# Altium schematic sheet 1_MISC.SchDoc(1_MISC)
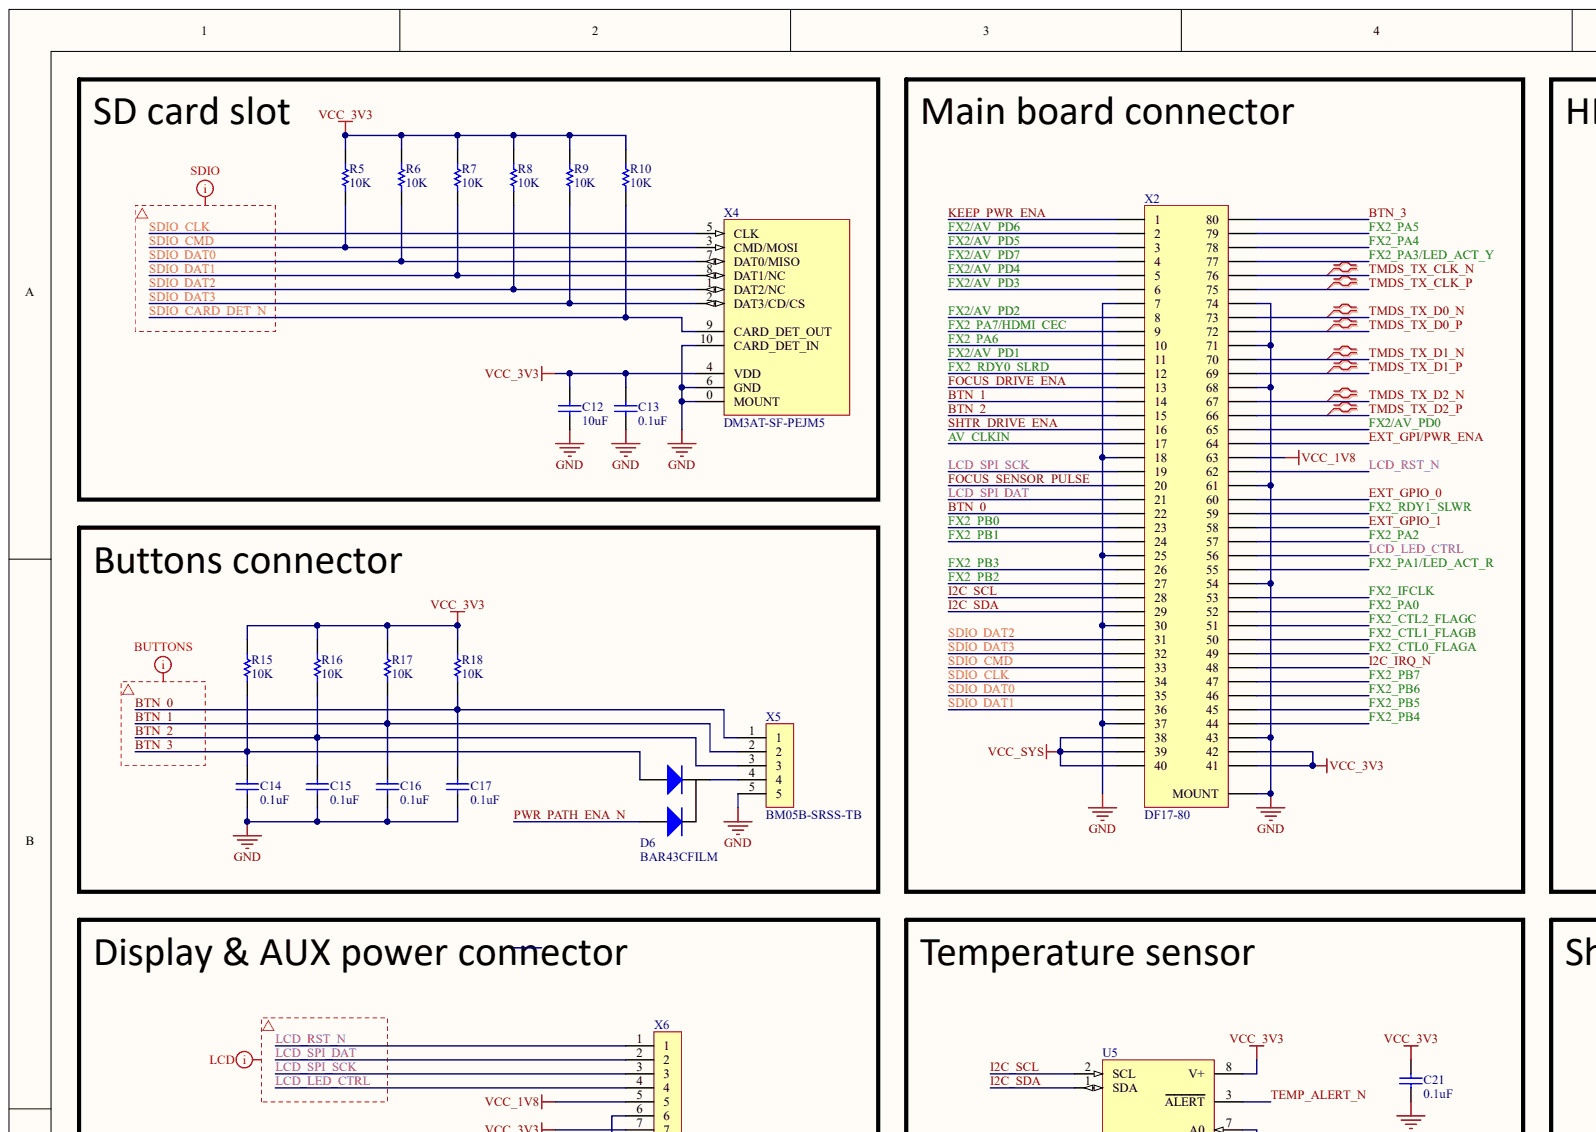
[diagram p0: 1_MISC.SchDoc, top left]
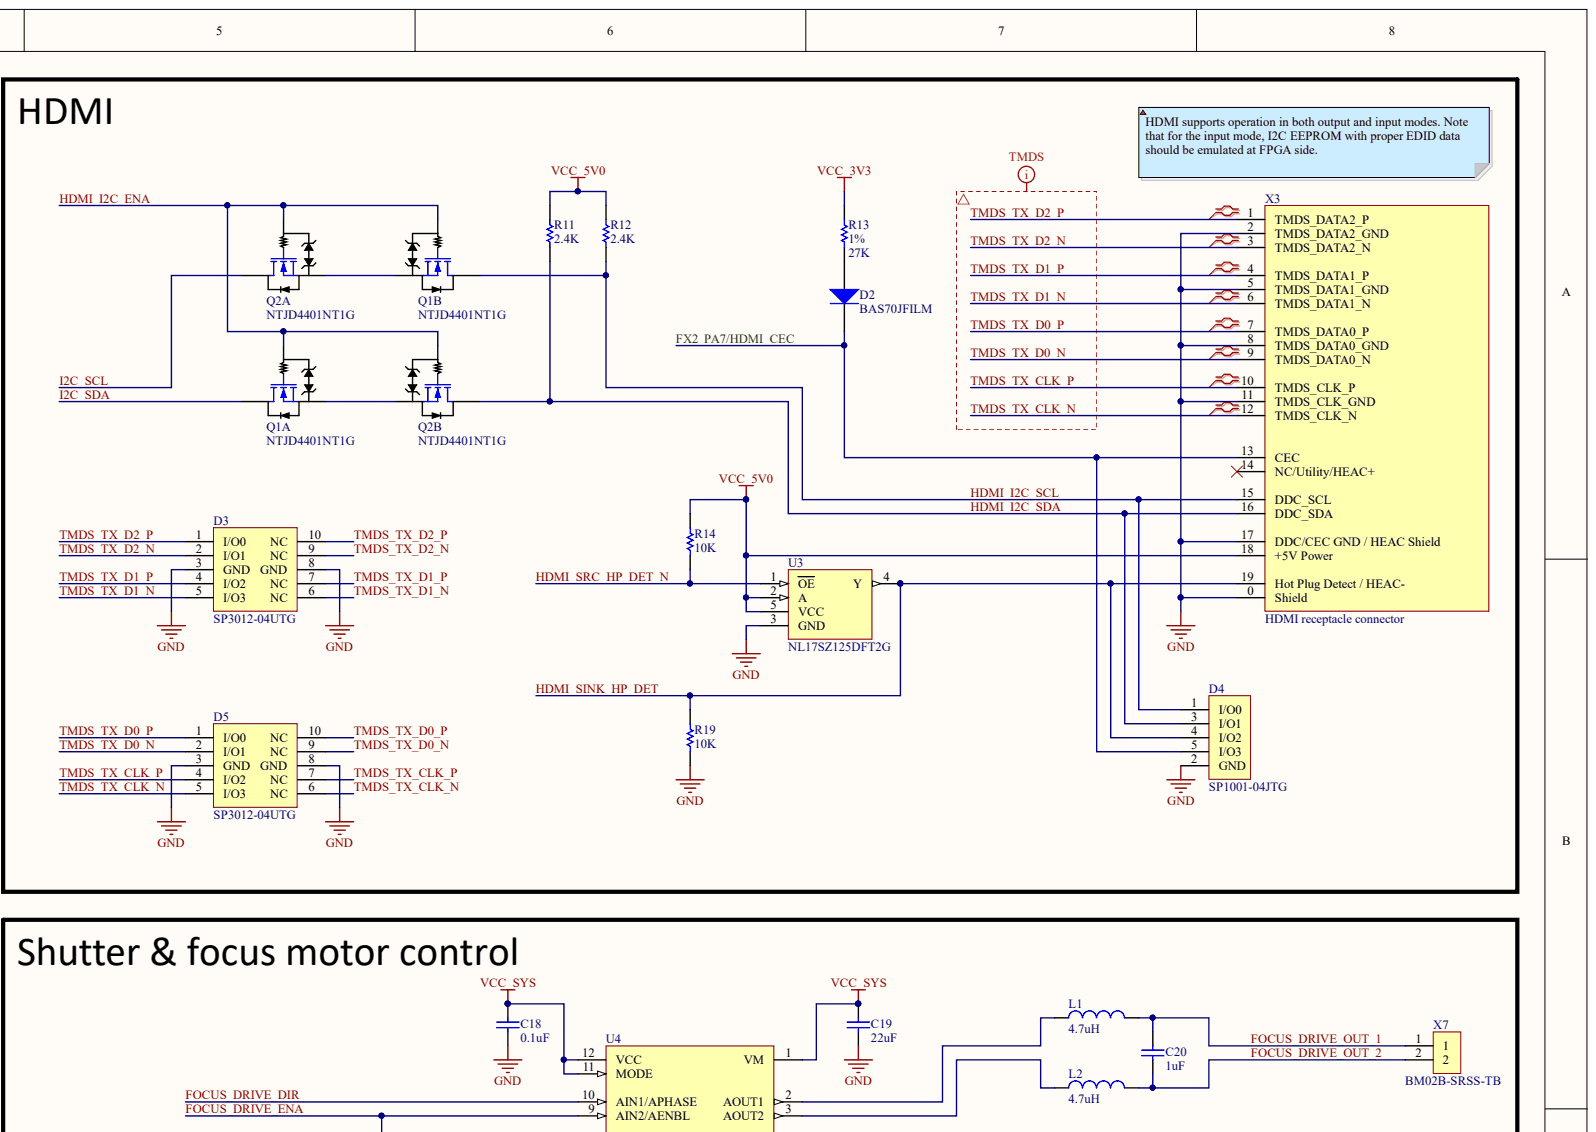
[diagram p1: 1_MISC.SchDoc, top right]
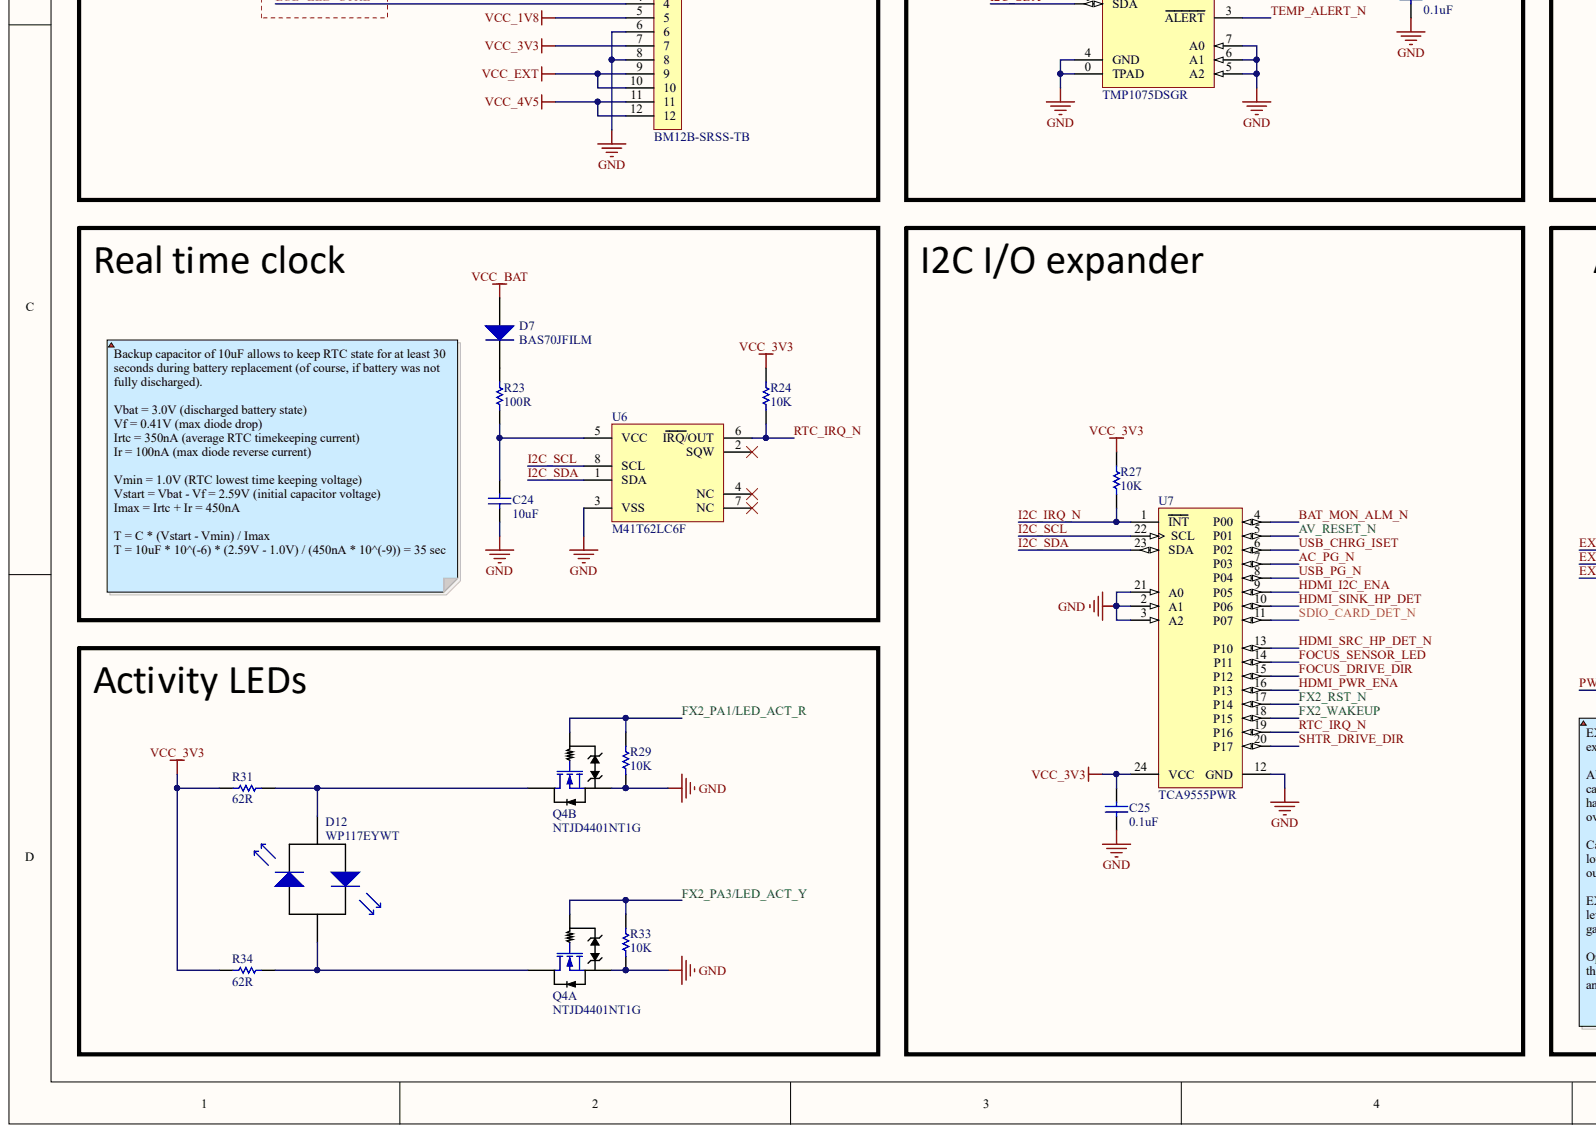
[diagram p2: 1_MISC.SchDoc, bottom left]
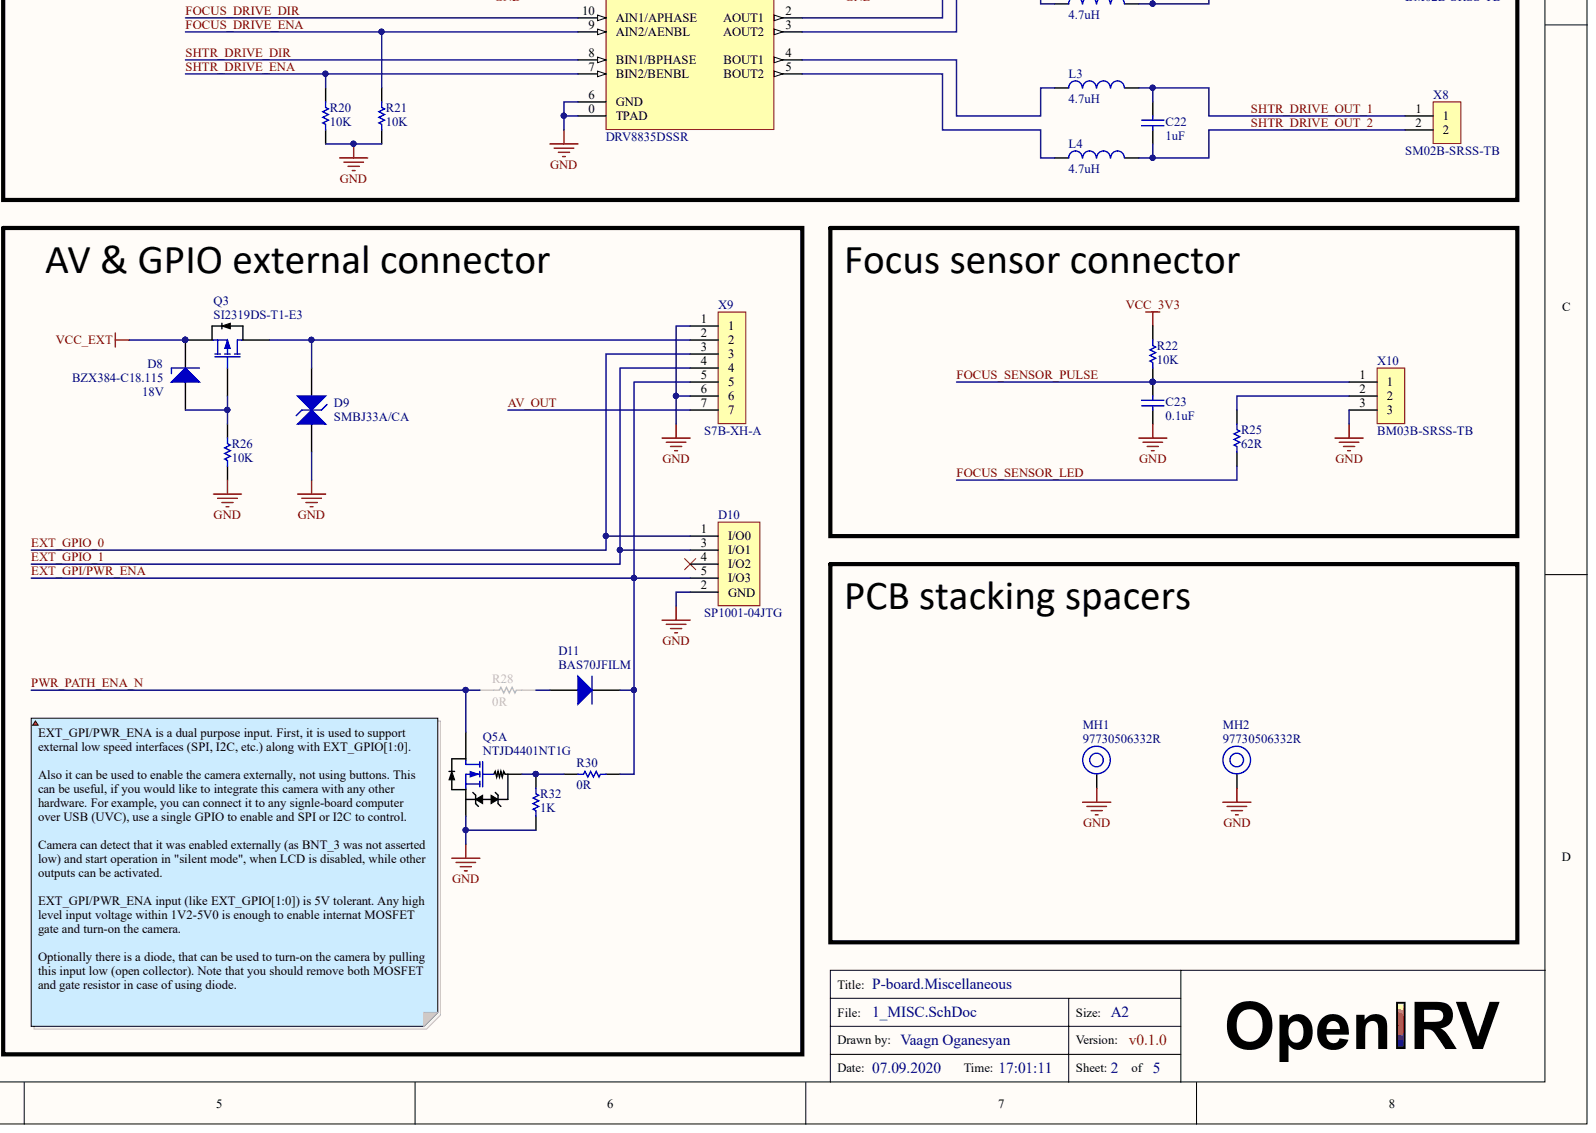
[diagram p3: 1_MISC.SchDoc, bottom right]

## Parts
C12  10uF  [C0805[2012]]
C13  0.1uF  [C0402[1005]]
C14  0.1uF  [C0402[1005]]
C15  0.1uF  [C0402[1005]]
C16  0.1uF  [C0402[1005]]
C17  0.1uF  [C0402[1005]]
C18  0.1uF  [C0402[1005]]
C19  22uF  [C1206[3216]]
C20  1uF  [C0603[1608]]
C21  0.1uF  [C0402[1005]]
C22  1uF  [C0603[1608]]
C23  0.1uF  [C0402[1005]]
C24  10uF  [C0805[2012]]
C25  0.1uF  [C0402[1005]]
D2  BAS70JFILM  [BAS70JFILM]
D3  SP3012-04UTG  [SP3012-04UTG]
D4  SP1001-04JTG  [SP1001-04JTG]
D5  SP3012-04UTG  [SP3012-04UTG]
D6  BAR43CFILM  [BAR43CFILM]
D7  BAS70JFILM  [BAS70JFILM]
D8  BZX384-C18.115  stab_voltage: 18V  [BZX384]
D9  SMBJ33A/CA  [SMBJ33A/CA]
D10  SP1001-04JTG  [SP1001-04JTG]
D11  BAS70JFILM  [BAS70JFILM]
D12  WP117EYWT  [LED_AXIAL[7x5x2]]
L1  value 4.7uH  [L0805[2012]]  (hidden comment: LQM21PN4R7MGRD)
L2  value 4.7uH  [L0805[2012]]  (hidden comment: LQM21PN4R7MGRD)
L3  value 4.7uH  [L0805[2012]]  (hidden comment: LQM21PN4R7MGRD)
L4  value 4.7uH  [L0805[2012]]  (hidden comment: LQM21PN4R7MGRD)
MH1  97730506332R  [97730506332R]
MH2  97730506332R  [97730506332R]
Q1  NTJD4401NT1G  [NTJD4401NT1G]  (parts A,B)
Q2  NTJD4401NT1G  [NTJD4401NT1G]  (parts A,B)
Q3  SI2319DS-T1-E3  [SI2319DS-T1-E3]
Q4  NTJD4401NT1G  [NTJD4401NT1G]  (parts A,B)
Q5  NTJD4401NT1G  [NTJD4401NT1G]  (part A)
R5  10K  [R0402[1005]]
R6  10K  [R0402[1005]]
R7  10K  [R0402[1005]]
R8  10K  [R0402[1005]]
R9  10K  [R0402[1005]]
R10  10K  [R0402[1005]]
R11  2.4K  [R0402[1005]]
R12  2.4K  [R0402[1005]]
R13  27K  Tolerance: 1%  [R0603[1608]]
R14  10K  [R0402[1005]]
R15  10K  [R0402[1005]]
R16  10K  [R0402[1005]]
R17  10K  [R0402[1005]]
R18  10K  [R0402[1005]]
R19  10K  [R0402[1005]]
R20  10K  [R0402[1005]]
R21  10K  [R0402[1005]]
R22  10K  [R0402[1005]]
R23  100R  [R0603[1608]]
R24  10K  [R0402[1005]]
R25  62R  [R0603[1608]]
R26  10K  [R0402[1005]]
R27  10K  [R0402[1005]]
R28  0R  [R0402[1005]]
R29  10K  [R0402[1005]]
R30  0R  [R0402[1005]]
R31  62R  [R0603[1608]]
R32  1K  [R0402[1005]]
R33  10K  [R0402[1005]]
R34  62R  [R0603[1608]]
U3  NL17SZ125DFT2G  [NL17SZ125DFT2G]
U4  DRV8835DSSR  [DRV8835DSSR]
U5  TMP1075DSGR  [TMP1075DSGR]
U6  M41T62LC6F  [M41T62LC6F]
U7  TCA9555PWR  [TCA9555PWR]
X2  DF17-80  [DF17(3.0)-80DS-0.5V(57)]
X3  HDMI receptacle connector  [471510001_EDGE_HOLE]
X4  DM3AT-SF-PEJM5  [DM3AT-SF-PEJM5]
X5  BM05B-SRSS-TB  [BM05B-SRSS-TB]
X6  BM12B-SRSS-TB  [BM12B-SRSS-TB]
X7  BM02B-SRSS-TB  [BM02B-SRSS-TB]
X8  SM02B-SRSS-TB  [SM02B-SRSS-TB]
X9  S7B-XH-A  [S7B-XH-A]
X10  BM03B-SRSS-TB  [BM03B-SRSS-TB]

## Nets
GND: C12.1 C13.2 C14.2 C15.2 C16.2 C17.2 C18.2 C19.1 C21.2 C23.2 C24.1 C25.2 D3.3(GND) D3.8(GND) D4.2(GND) D5.3(GND) D5.8(GND) D9.1 D10.2(GND) MH1.0 MH2.0 Q4.1 Q4.4 Q5.1 R19.2 R20.1 R21.1 R26.2 R29.1 R32.2 R33.1 U3.3(GND) U4.0(TPAD) U4.6(GND) U5.0(TPAD) U5.4(GND) U5.5(A2) U5.6(A1) U5.7(A0) U6.3(VSS) U7.2(A1) U7.3(A2) U7.12(GND) U7.21(A0) X2.0(MOUNT) X2.7 X2.18 X2.25 X2.30 X2.37 X2.43 X2.54 X2.61 X2.68 X2.71 X2.74 X3.0(Shield) X3.2(TMDS_DATA2_GND) X3.5(TMDS_DATA1_GND) X3.8(TMDS_DATA0_GND) X3.11(TMDS_CLK_GND) X3.17(DDC/CEC_GND_/_HEAC_Shield) X4.0(MOUNT) X4.6(GND) X4.10(CARD_DET_IN) X5.5 X6.6 X6.8 X9.1 X9.6 X10.3
VCC_1V8: X2.63 X6.5
VCC_3V3: C12.2 C13.1 C21.1 C25.1 R5.2 R6.2 R7.2 R8.2 R9.2 R10.2 R13.1 R15.1 R16.2 R17.2 R18.2 R22.2 R24.1 R27.1 R31.1 R34.1 U5.8(V+) U7.24(VCC) X2.41 X2.42 X4.4(VDD) X6.7
VCC_4V5: X6.11 X6.12
VCC_5V0: R11.2 R12.2 R14.2 U3.2(A) U3.5(VCC) X3.18(+5V_Power)
VCC_BAT: D7.1
VCC_EXT: D8.2 Q3.2 X6.9 X6.10
VCC_SYS: C18.1 C19.2 U4.1(VM) U4.11(MODE) U4.12(VCC) X2.38 X2.39 X2.40
AC_PG_N: U7.7(P03)
AV_CLKIN: X2.17
AV_OUT: X9.7
AV_RESET_N: U7.5(P01)
BAT_MON_ALM_N: U7.4(P00)
BTN_0: C17.1 R18.1 X2.22 X5.1
BTN_1: C16.1 R17.1 X2.14 X5.2
BTN_2: C15.1 R16.1 X2.15 X5.3
BTN_3: C14.1 D6.1 R15.2 X2.80
EXT_GPI/PWR_ENA: D10.5(I/O3) D11.2 R30.1 X2.64 X9.5
EXT_GPIO_0: D10.1(I/O0) X2.60 X9.3
EXT_GPIO_1: D10.3(I/O1) X2.58 X9.4
FOCUS_DRIVE_DIR: U4.10(AIN1/APHASE) U7.15(P12)
FOCUS_DRIVE_ENA: R21.2 U4.9(AIN2/AENBL) X2.13
FOCUS_DRIVE_OUT_1: C20.1 L1.2 X7.1
FOCUS_DRIVE_OUT_2: C20.2 L2.2 X7.2
FOCUS_SENSOR_LED: R25.1 U7.14(P11)
FOCUS_SENSOR_PULSE: C23.1 R22.1 X2.20 X10.1
FX2/AV_PD0: X2.65
FX2/AV_PD1: X2.11
FX2/AV_PD2: X2.8
FX2/AV_PD3: X2.6
FX2/AV_PD4: X2.5
FX2/AV_PD5: X2.3
FX2/AV_PD6: X2.2
FX2/AV_PD7: X2.4
FX2_CTL0_FLAGA: X2.49
FX2_CTL1_FLAGB: X2.50
FX2_CTL2_FLAGC: X2.51
FX2_IFCLK: X2.53
FX2_PA0: X2.52
FX2_PA1/LED_ACT_R: Q4.5 R29.2 X2.55
FX2_PA2: X2.57
FX2_PA3/LED_ACT_Y: Q4.2 R33.2 X2.77
FX2_PA4: X2.78
FX2_PA5: X2.79
FX2_PA6: X2.10
FX2_PA7/HDMI_CEC: D2.2 D4.5(I/O3) X2.9 X3.13(CEC)
FX2_PB0: X2.23
FX2_PB1: X2.24
FX2_PB2: X2.27
FX2_PB3: X2.26
FX2_PB4: X2.44
FX2_PB5: X2.45
FX2_PB6: X2.46
FX2_PB7: X2.47
FX2_RDY0_SLRD: X2.12
FX2_RDY1_SLWR: X2.59
FX2_RST_N: U7.17(P14)
FX2_WAKEUP: U7.18(P15)
HDMI_I2C_ENA: Q1.2 Q1.5 Q2.2 Q2.5 U7.9(P05)
HDMI_I2C_SCL: D4.1(I/O0) Q1.3 R12.1 X3.15(DDC_SCL)
HDMI_I2C_SDA: D4.3(I/O1) Q2.3 R11.1 X3.16(DDC_SDA)
HDMI_PWR_ENA: U7.16(P13)
HDMI_SINK_HP_DET: D4.4(I/O2) R19.1 U3.4(Y) U7.10(P06) X3.19(Hot_Plug_Detect_/_HEAC-)
HDMI_SRC_HP_DET_N: R14.1 U3.1(OE) U7.13(P10)
I2C_IRQ_N: R27.2 U7.1(INT) X2.48
I2C_SCL: Q2.6 U5.2(SCL) U6.8(SCL) U7.22(SCL) X2.28
I2C_SDA: Q1.6 U5.1(SDA) U6.1(SDA) U7.23(SDA) X2.29
KEEP_PWR_ENA: X2.1
LCD_LED_CTRL: X2.56 X6.4
LCD_RST_N: X2.62 X6.1
LCD_SPI_DAT: X2.21 X6.2
LCD_SPI_SCK: X2.19 X6.3
PWR_PATH_ENA_N: D6.2 Q5.6 R28.1
RTC_IRQ_N: R24.2 U6.6(IRQ/OUT) U7.19(P16)
SDIO_CARD_DET_N: R10.1 U7.11(P07) X4.9(CARD_DET_OUT)
SDIO_CLK: X2.34 X4.5(CLK)
SDIO_CMD: R5.1 X2.33 X4.3(CMD/MOSI)
SDIO_DAT0: R6.1 X2.35 X4.7(DAT0/MISO)
SDIO_DAT1: R7.1 X2.36 X4.8(DAT1/NC)
SDIO_DAT2: R8.1 X2.31 X4.1(DAT2/NC)
SDIO_DAT3: R9.1 X2.32 X4.2(DAT3/CD/CS)
SHTR_DRIVE_DIR: U4.8(BIN1/BPHASE) U7.20(P17)
SHTR_DRIVE_ENA: R20.2 U4.7(BIN2/BENBL) X2.16
SHTR_DRIVE_OUT_1: C22.1 L3.2 X8.1
SHTR_DRIVE_OUT_2: C22.2 L4.2 X8.2
TEMP_ALERT_N: U5.3(ALERT)
TMDS_TX_CLK_N: D5.5(I/O3) D5.6(NC) X2.76 X3.12(TMDS_CLK_N)
TMDS_TX_CLK_P: D5.4(I/O2) D5.7(NC) X2.75 X3.10(TMDS_CLK_P)
TMDS_TX_D0_N: D5.2(I/O1) D5.9(NC) X2.73 X3.9(TMDS_DATA0_N)
TMDS_TX_D0_P: D5.1(I/O0) D5.10(NC) X2.72 X3.7(TMDS_DATA0_P)
TMDS_TX_D1_N: D3.5(I/O3) D3.6(NC) X2.70 X3.6(TMDS_DATA1_N)
TMDS_TX_D1_P: D3.4(I/O2) D3.7(NC) X2.69 X3.4(TMDS_DATA1_P)
TMDS_TX_D2_N: D3.2(I/O1) D3.9(NC) X2.67 X3.3(TMDS_DATA2_N)
TMDS_TX_D2_P: D3.1(I/O0) D3.10(NC) X2.66 X3.1(TMDS_DATA2_P)
USB_CHRG_ISET: U7.6(P02)
USB_PG_N: U7.8(P04)
NetC24_2: C24.2 R23.2 U6.5(VCC)
NetD2_1: D2.1 R13.2
NetD6_3: D6.3 X5.4
NetD7_2: D7.2 R23.1
NetD8_1: D8.1 Q3.1 R26.1
NetD9_2: D9.2 Q3.3 X9.2
NetD11_1: D11.1 R28.2
NetD12_1: D12.1 Q4.3 R31.2
NetD12_2: D12.2 Q4.6 R34.2
NetL1_1: L1.1 U4.2(AOUT1)
NetL2_1: L2.1 U4.3(AOUT2)
NetL3_1: L3.1 U4.4(BOUT1)
NetL4_1: L4.1 U4.5(BOUT2)
NetQ1_1: Q1.1 Q2.4
NetQ1_4: Q1.4 Q2.1
NetQ5_2: Q5.2 R30.2 R32.1
NetR25_2: R25.2 X10.2
N00066:
N00067:
N00068:
N00069:
N00070:

Not connected: D10 4(I/O2); U6 2(SQW) 4(NC) 7(NC); X3 14(NC/Utility/HEAC+)

## Library data of the parts
C12 C19 C24 = CAP: Dielectric: X7R; Tolerance: 10%; Voltage: 10V; Component Kind: Standard; Library Name: general.IntLib; Mounting: SMD
  C12 C24: Value: 10uF
  C19: Value: 22uF
C13-C18 C21 C23 C25 = CAP: Dielectric: X7R; Tolerance: 10%; Voltage: 50V; Component Kind: Standard; Library Name: general.IntLib; Mounting: SMD; Value: 0.1uF
C20 C22 = CAP: Dielectric: X7R; Tolerance: 10%; Voltage: 25V; Component Kind: Standard; Library Name: general.IntLib; Mounting: SMD; Value: 1uF
D2 D7 D11 = Diode_1: Diode; Component Kind: Standard; Library Name: general.IntLib; ManufacturerPartNumber: BAS70JFILM
D3 D5 = SP3012-04UTG: ESD protection diode; Component Kind: Standard; Library Name: general.IntLib
D4 D10 = SP1001-04JTG: ESD protection diode; Component Kind: Standard; Library Name: general.IntLib
D6 = Diode_2: Component Kind: Standard; Library Name: general.IntLib
D8 = Diode_3: Zener diode; Component Kind: Standard; Library Name: general.IntLib; ManufacturerPartNumber: BZX384-C18.115
D9 = Diode_5: TVS diode; Component Kind: Standard; Library Name: general.IntLib; ManufacturerPartNumber: SMBJ33A/CA
D12 = LED_DUAL: Component Kind: Standard; Library Name: general.IntLib; ManufacturerPartNumber: WP117EYWT
L1-L4 = Inductor: Component Kind: Standard; Library Name: general.IntLib; ManufacturerPartNumber: LQM21PN4R7MGRD
MH1 MH2 = 97730506332R: Spacer M1.6; Component Kind: Standard; Library Name: general.IntLib
Q1 Q2 Q4 Q5 = NTJD4401NT1G: Dual N-channel mosfet; Component Kind: Standard; Library Name: general.IntLib
R5-R12 R14-R22 R24 R26-R30 R32 R33 = RES: Power: 0.06W; Tolerance: 5%; Component Kind: Standard; Library Name: general.IntLib; Mounting: SMD
  R5-R10 R14-R22 R24 R26 R27 R29 R33: Value: 10K
  R11 R12: Value: 2.4K
  R28 R30: Value: 0R
  R32: Value: 1K
R13 = RES: Power: 0.1W; Component Kind: Standard; Library Name: general.IntLib; Mounting: SMD; Value: 27K
R23 R25 R31 R34 = RES: Power: 0.1W; Tolerance: 5%; Component Kind: Standard; Library Name: general.IntLib; Mounting: SMD
  R23: Value: 100R
  R25 R31 R34: Value: 62R
U3 = NL17SZ125DFT2G: Non-Inverting 3-State Buffer; Component Kind: Standard; Library Name: general.IntLib
U4 = DRV8835DSSR: Dual Low Voltage H-Bridge IC; Component Kind: Standard; Library Name: general.IntLib
U5 = TMP1075DSGR: Temperature sensor I2C 12-bit; Component Kind: Standard; Library Name: general.IntLib
U6 = M41T62LC6F: Real Time Clock; Component Kind: Standard; Library Name: general.IntLib
U7 = TCA9555PWR: Remote 16-Bit I2C and SMBus I/O Expander with Interrupt Output and Configuration Registers, 1.65 to 5.5 V, -40 to 85 degC, 24-pin TSSOP (PW), Green (RoHS & no Sb/Br); Manufacturer: Texas Instruments; # of I/Os: 16; 5V Tolerant I/O: Yes; I2C Address: 0100 xxx; Max Frequency(kHz): 400; SubFamily: I2C IO Expander; Code_JEDEC: MO-153; Component Kind: Standard; ComponentLink1Description: Datasheet; ComponentLink1URL: http://www.ti.com/general/docs/lit/getliterature.tsp?genericPartNumber=TCA9555&fileType=pdf; ComponentLink2Description: Package Specification; ComponentLink2URL: http://www.ti.com/litv/pdf/mpds177g; Configuration Registers: Yes; DatasheetVersion: SCPS200A; Eco-Plan: Green (RoHS & no Sb/Br); Interrupt Output: Yes; Library Name: general.IntLib; Mounting Technology: Surface Mount; PackageDescription: 24-Pin Thin Small Outline Package 0.65 mm Pitch; PackageReference: PW24; PackageVersion: revG, Feb-2011; PartNumber: TCA9555PWR; Push-Pull I/O Type: Yes; Supplier 1: Digi-Key; Supplier 2: Digi-Key; Supplier 3: Digi-Key; Supplier 4: Newark; Supplier 5: Mouser; Supplier Part Number 1: 296-24827-2-ND; Supplier Part Number 2: 296-24827-1-ND; Supplier Part Number 3: 296-24827-6-ND; Supplier Part Number 4: 24R9978; Supplier Part Number 5: 595-TCA9555PWR; Vcc range(V): 1.65 to 5.5
X3 = 471510001: Component Kind: Standard; Library Name: general.IntLib
X9 = S7B-XH-A: JST connector 2.5mm 7-pin; Component Kind: Standard; Library Name: general.IntLib
Q3 = SI2319DS-T1-E3: Component Kind: Standard; Library Name: general.IntLib
X2 = DF17-80: Component Kind: Standard; Library Name: general.IntLib
X4 = DM3AT-SF-PEJM5: Component Kind: Standard; Library Name: general.IntLib
X5 = BM05B-SRSS-TB: Component Kind: Standard; Library Name: general.IntLib
X6 = BM12B-SRSS-TB: Component Kind: Standard; Library Name: general.IntLib
X7 = BM02B-SRSS-TB: Component Kind: Standard; Library Name: general.IntLib
X8 = SM02B-SRSS-TB: Component Kind: Standard; Library Name: general.IntLib
X10 = BM03B-SRSS-TB: Component Kind: Standard; Library Name: general.IntLib
